# Altium schematic sheet FlightComp.SchDoc(FlightComp)
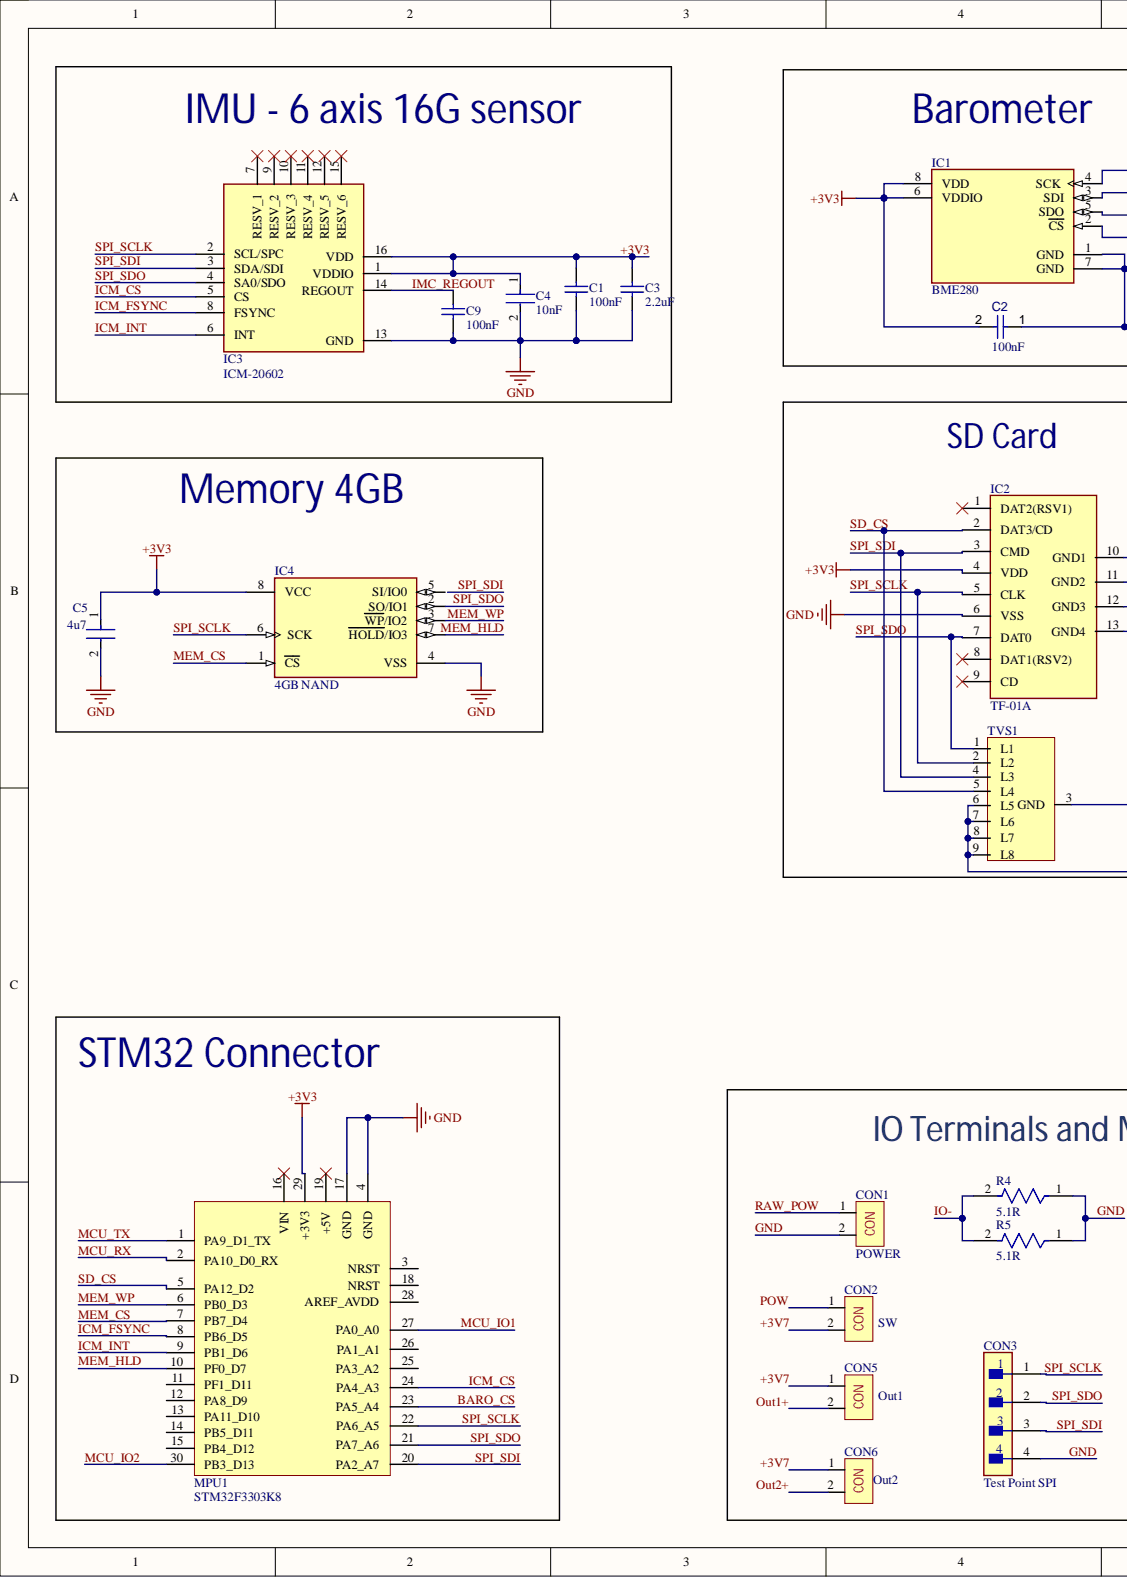
[diagram p0: FlightComp.SchDoc, left]
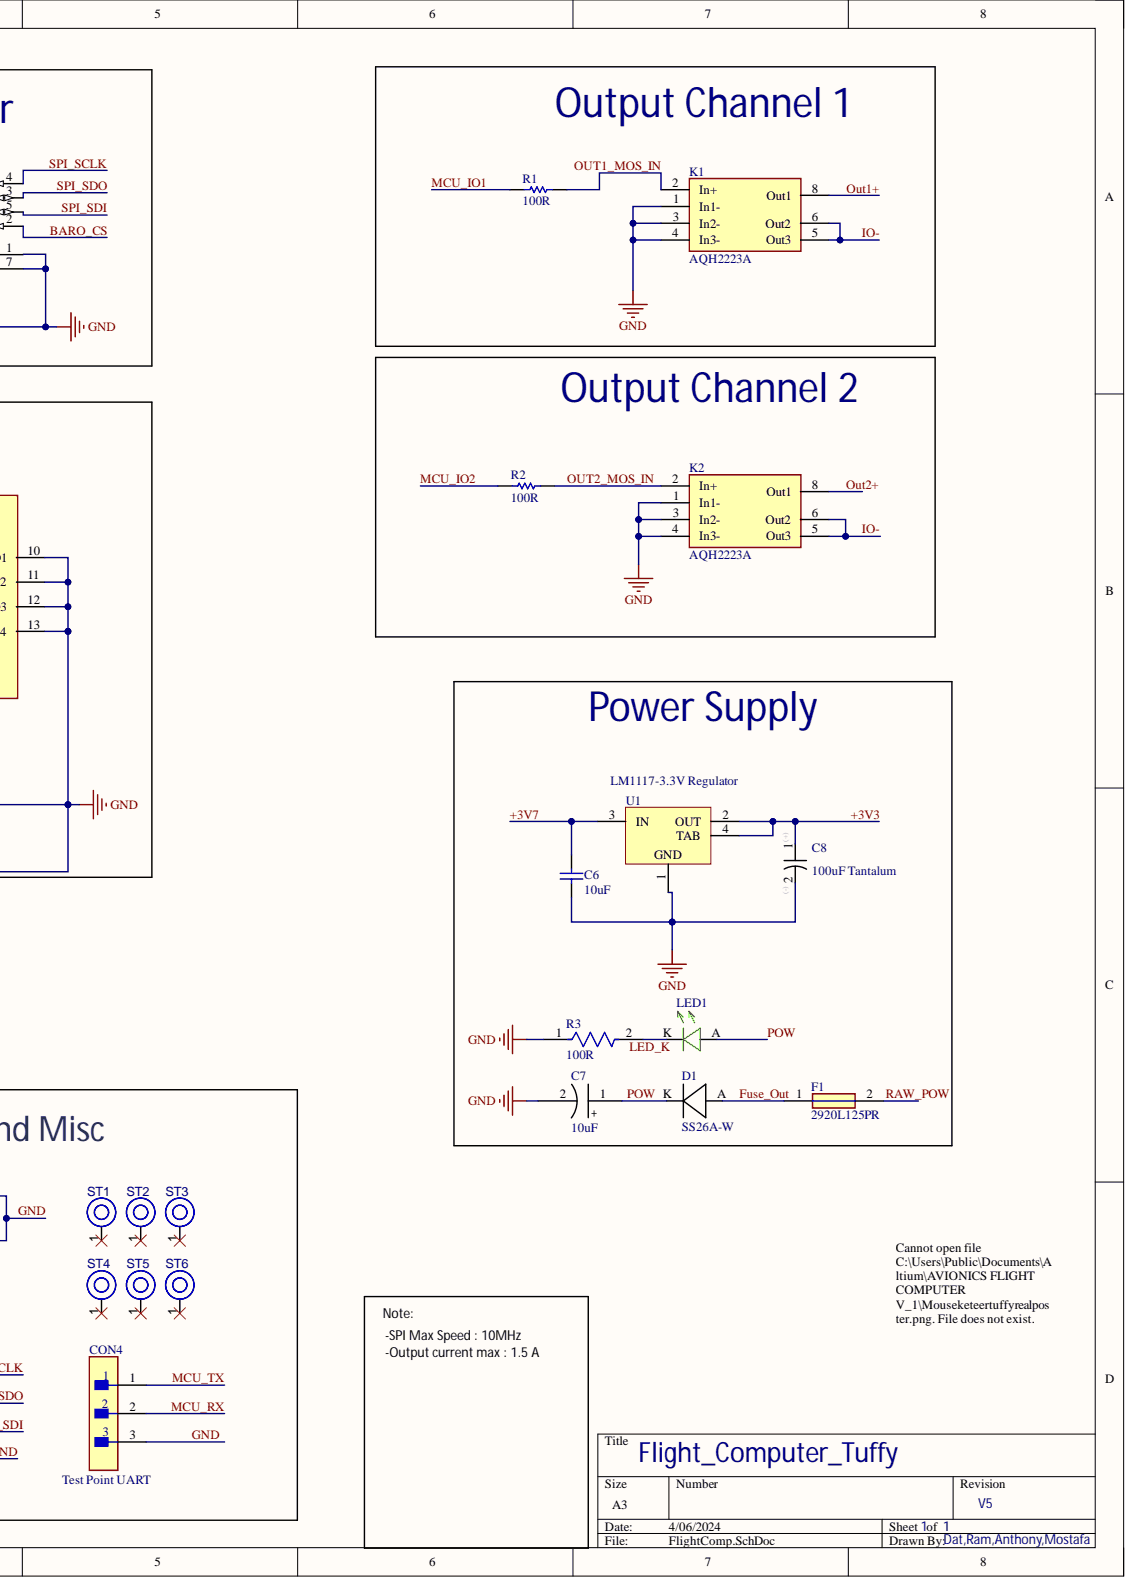
[diagram p1: FlightComp.SchDoc, right]

## Parts
C1  100nF
C2  value 100nF  (hidden comment: Capacitor 0.1 uF +/- 5% 16 V 0402)
C3  2.2uF
C4  10nF
C5  4u7
C6  10uF
C7  10uF
C8  100uF Tantalum  value 100uF
C9  100nF
CON1  POWER
CON2  SW
CON3  Test Point SPI
CON4  Test Point UART
CON5  Out1
CON6  Out2
D1  SS26A-W
F1  2920L125PR
IC1  BME280
IC2  TF-01A
IC3  ICM-20602
IC4  4GB NAND
K1  AQH2223A
K2  AQH2223A
LED1  SZYY0603YG  (value not drawn)
MPU1  STM32F3303K8
R1  100R
R2  100R
R3  100R
R4  5.1R
R5  5.1R
ST1  PTH-M2D5X5  (value not drawn)
ST2  PTH-M2D5X5  (value not drawn)
ST3  PTH-M2D5X5  (value not drawn)
ST4  PTH-M2D5X5  (value not drawn)
ST5  PTH-M2D5X5  (value not drawn)
ST6  PTH-M2D5X5  (value not drawn)
TVS1  D3V3X8U9LP3810  (value not drawn)
U1  LM1117-3.3V Regulator

## Nets
+3V3: C1.2 C2.2 C3.2 C4.1 C5.1 C8.+ IC1.6(VDDIO) IC1.8(VDD) IC2.4(VDD) IC3.1(VDDIO) IC3.16(VDD) IC4.8(VCC) MPU1.29(+3V3) U1.2(OUT) U1.4(TAB)
+3V7: C6.2 CON2.2 CON5.1 CON6.1 U1.3(IN)
BARO_CS: IC1.2(CS) MPU1.23(PA5_A4)
Fuse_Out: D1.A F1.1
GND: C1.1 C2.1 C3.1 C4.2 C5.2 C6.1 C7.2 C8.- C9.1 CON1.2 CON3.4 CON4.3 IC1.7(GND) IC2.6(VSS) IC2.10(GND1) IC2.11(GND2) IC2.12(GND3) IC2.13(GND4) IC3.13(GND) IC4.4(VSS) K1.1(In1-) K1.3(In2-) K1.4(In3-) K2.1(In1-) K2.3(In2-) K2.4(In3-) MPU1.4(GND) MPU1.17(GND) R3.1 R4.1 R5.1 TVS1.3(GND) TVS1.6(L5) TVS1.7(L6) TVS1.8(L7) TVS1.9(L8) U1.1
ICM_CS: IC3.5(CS) MPU1.24(PA4_A3)
ICM_FSYNC: IC3.8(FSYNC) MPU1.8(PB6_D5)
ICM_INT: IC3.6(INT) MPU1.9(PB1_D6)
IMC_REGOUT: C9.2 IC3.14(REGOUT)
IO-: K1.5(Out3) K1.6(Out2) K2.5(Out3) K2.6(Out2) R4.2 R5.2
LED_K: LED1.K R3.2
MCU_IO1: MPU1.27(PA0_A0) R1.1
MCU_IO2: MPU1.30(PB3_D13) R2.1
MCU_RX: CON4.2 MPU1.2(PA10_D0_RX)
MCU_TX: CON4.1 MPU1.1(PA9_D1_TX)
MEM_CS: IC4.1(CS) MPU1.7(PB7_D4)
MEM_HLD: IC4.7(HOLD/IO3) MPU1.10(PF0_D7)
MEM_WP: IC4.3(WP/IO2) MPU1.6(PB0_D3)
Out1+: CON5.2 K1.2(In+) K1.8(Out1) K2.2(In+) R1.2 R2.2  [also labelled OUT1_MOS_IN, OUT2_MOS_IN]
Out2+: CON6.2 K2.8(Out1)
POW: C7.1 CON2.1 D1.K LED1.A
RAW_POW: CON1.1 F1.2
SD_CS: IC2.2(DAT3/CD) MPU1.5(PA12_D2) TVS1.5(L4)
SPI_SCLK: CON3.1 IC1.4(SCK) IC2.5(CLK) IC3.2(SCL/SPC) IC4.6(SCK) MPU1.22(PA6_A5) TVS1.2(L2)
SPI_SDI: CON3.3 IC1.5(SDO) IC2.3(CMD) IC3.3(SDA/SDI) MPU1.20(PA2_A7) TVS1.4(L3)
SPI_SDO: CON3.2 IC1.3(SDI) IC2.7(DAT0) IC3.4(SA0/SDO) IC4.2(SO/IO1) MPU1.21(PA7_A6) TVS1.1(L1)

Not connected: IC1 1(GND); IC2 1(DAT2(RSV1)) 8(DAT1(RSV2)) 9(CD); IC3 7(RESV_1) 9(RESV_2) 10(RESV_3) 11(RESV_4) 12(RESV_5) 15(RESV_6); IC4 5(SI/IO0); MPU1 3(NRST) 11(PF1_D11) 12(PA8_D9) 13(PA11_D10) 14(PB5_D11) 15(PB4_D12) 16(VIN) 18(NRST) 19(+5V) 25(PA3_A2) 26(PA1_A1) 28(AREF_AVDD); ST1 1; ST2 1; ST3 1; ST4 1; ST5 1; ST6 1

## Library data of the parts
C1 C9 = CMP-0001-00074-2: Manufacturer: Kemet; Part Number: C0402C104K4RACTU; Component Kind: Standard; JLCPCB Part #: C2655418; Library Name: Altium Content Vault
C2 = CMP-001-00001-6: Chip Capacitor, 0.1 uF, +/- 5%, 16 V, 0402 (1005 Metric); Capacitor Type: Ceramic; Voltage Rating: 16V; Case/Package: 0402; Component Kind: Standard; JLCPCB Part #: C387941; Max Operating Temperature: 125°C; Min Operating Temperature: -55°C; Mounting Technology: SM; Pins: 2; RoHS Compliant: True; Tolerance: 5%; Library Name: Ram ganesh
C3 = CMP-2007-00007-1: Manufacturer: Kemet; Part Number: C0805C225K8RACTU; Component Kind: Standard; JLCPCB Part #: C342819; Library Name: Altium Content Vault
C4 = CMP-2010-00230-2: General Purpose Ceramic Capacitor, 0201, 10nF, 10%, X7R, 15%, 10V; Capacitance: 10nF; Case Code (Imperial): 0201; Case Code (Metric): 0603; Case/Package: 0201; Component Kind: Standard; Construction: Flat; Contact Plating: Tin; Depth: 0.3mm; Dielectric: X7R; Height: 0.33mm; JLCPCB Part #: C76941; Lead Free: Lead Free; Length: 0.6mm; Library Name: Altium Content Vault; Max Operating Temperature: 125°C; Min Operating Temperature: -55°C; Mount: Surface Mount; Package Quantity: 15000; Packaging: Tape and Reel; Radiation Hardening: No; REACH SVHC: No SVHC; RoHS Compliant: Yes; Series: -; Termination: SMD/SMT; Tolerance: 10%; Voltage: 10V; Voltage Rating: 10V; Voltage Rating (DC): 10V
C5 = CMP-2000-05997-2: CAP CER 4.7UF 10V X5R 0402; Dielectric Material: Ceramic; Tolerance: 10%; Capacitance: 4.7uF; Case Code (Imperial): 0402; Case Code (Metric): 1005; Case/Package: 0402; Component Kind: Standard; Dielectric: X5R; JLCPCB Part #: C368809; Lead Free: Lead Free; Length: 0.039inch; Library Name: Altium Content Vault; Max Operating Temperature: 85°C; Min Operating Temperature: -55°C; Mount: Surface Mount; Package Quantity: 10000; Packaging: Cut Tape; REACH SVHC: No SVHC; RoHS Compliant: Yes; Series: C; Thickness: 0.022inch; Voltage Rating: 10V; Voltage Rating (DC): 10V; Width: 0.02inch
C6 = CMP-1037-04961-1: CAP 10uF 6.3V ±10% 1206 (3216 Metric) Thickness 1.9mm SMD; Case-EIA: 1206; Case-Metric: 3216; Tolerance: Â±10%; Component Kind: Standard; JLCPCB Part #: C157351; Library Name: Altium Content Vault; Max Thickness: 1.9 mm; Rated Voltage: 6.3 V; Technology: SMT; Value: 10uF
C7 = RVT2A100M0607: 10uF 100V 38mA@120Hz ±20% SMD,D6.3xL7.7mm Aluminum Electrolytic Capacitors - SMD ROHS; Capacitance: 10uF; Component Kind: Standard; ComponentLink1Description: Info; ComponentLink1URL: https://jlcpcb.com/partdetail/Roqang-RVT2A100M0607/C72482; JLCPCB Part #: C72482; Library Name: FlightComputer.SchLib
C8 = T55A107M6R3C0150: 100uF 6.3V 150mΩ@100kHz ±20% 1206 Tantalum Capacitors ROHS; Parameter 1: C2158718; Component Kind: Standard; ComponentLink1Description: Part Shop; ComponentLink1URL: https://jlcpcb.com/partdetail/VishayIntertech-T55A107M6R3C0150/C2158718; JLCPCB Part #: C2158718; Library Name: FlightComputer.SchLib
CON1 CON2 CON5 CON6 = 4948978-2 (RS Stock): RS PRO PCB Terminal Block, 2-Contact, 5mm Pitch, Through Hole Mount, 1-Row, Screw Termination; Component Kind: Standard; ComponentLink1Description: Data Sheet; ComponentLink1URL: https://au.rs-online.com/web/p/pcb-terminal-blocks/4948978; Library Name: FlightComputer.SchLib
CON3 = PZ254V-11-04P: 250V 3A Straight Square Pins 2.5mm 4P 6mm -40℃~+105℃ 3mm 2.54mm Plugin Black 1x4P Plugin,P=2.54mm Pin Headers ROHS; Component Kind: Standard; Library Name: FlightComputer.SchLib
CON4 = PZ254V-11-03P: 250V 3A Straight Square Pins 2.5mm 3P 6mm -40℃~+105℃ 3mm 2.54mm Plugin Black 1x3P Plugin,P=2.54mm Pin Headers ROHS; Component Kind: Standard; ComponentLink1Description: Data Sheet; ComponentLink1URL: https://jlcpcb.com/partdetail/Xfcn-PZ254V_1103P/C2937625; Library Name: FlightComputer.SchLib
F1 = 2920L125PR: Fuse; Manufacturer_Name: LITTELFUSE; Arrow Part Number: 2920L125PR; Arrow Price/Stock: https://www.arrow.com/en/products/2920l125pr/littelfuse?region=nac; Component Kind: Standard; Datasheet Link: https://datasheet.lcsc.com/szlcsc/1907051732_Littelfuse-2920L125PR_C192524.pdf; Height: 1mm; JLCPCB Part #: C192524; Manufacturer_Part_Number: 2920L125PR; Mouser Part Number: 576-2920L125; Mouser Price/Stock: https://www.mouser.co.uk/ProductDetail/Littelfuse/2920L125PR?qs=SJZ%252BTX%252BI2BSHRP6GPsgTlw%3D%3D
IC1 = CMP-2000-05073-3: SENSOR PRESSURE HUMIDITY TEMP; Accuracy: 3%; Output Type: SPI; Response Time: 1s; Sensor Type: Temperature; Case/Package: LGA; Component Kind: Standard; JLCPCB Part #: C92489; Lead Free: Lead Free; Library Name: Altium Content Vault; Max Operating Temperature: 85°C; Min Operating Temperature: -40°C; Mount: Surface Mount; Number of Pins: 8; Packaging: Cut Tape
IC2 = TF-01A: Connector; Manufacturer_Name: Korean Hroparts Elec; Component Kind: Standard; Datasheet Link: https://datasheet.lcsc.com/szlcsc/1903221101_Korean-Hroparts-Elec-TF-01A_C91145.pdf; Height: 1.85mm; JLCPCB Part #: C91145; Library Name: FlightComputer.SchLib; Manufacturer_Part_Number: TF-01A
IC3 = ICM-20602: High Performance 6-Axis MEMS MotionTracking™ Device; Manufacturer_Name: TDK-Lambda; Arrow Part Number: ICM-20602; Arrow Price/Stock: https://www.arrow.com/en/products/icm-20602/invensense?region=nac; Component Kind: Standard; Datasheet Link: https://3cfeqx1hf82y3xcoull08ihx-wpengine.netdna-ssl.com/wp-content/uploads/2021/03/DS-000135-ICG-20660-TYP-v1.2.pdf; JLCPCB Part #: C97633; Library Name: FlightComputer.SchLib; Manufacturer_Part_Number: ICM-20602; Mouser Part Number: 410-ICM-20602; Mouser Price/Stock: https://www.mouser.co.uk/ProductDetail/TDK-InvenSense/ICM-20602?qs=u4fy%2FsgLU9NtDChFbqTxBA%3D%3D
IC4 = CMP-14958-000017-1: IC FLASH 4GBIT SPI UPDFN; Memory Type: Non-Volatile; Component Kind: Standard; JLCPCB Part #: C2909506; Library Name: Altium Content Vault; Max Operating Temperature: 85°C; Memory Size: 4Gb; Min Operating Temperature: -40°C; Packaging: Box; Series: -
K1 K2 = AQH2223A: Relay or Contactor; Manufacturer_Name: Panasonic; Component Kind: Standard; Datasheet Link: https://b2b-api.panasonic.eu/file_stream/pids/fileversion/2787; Height: 3.9mm; JLCPCB Part #: C153026; Library Name: FlightComputer.SchLib; Manufacturer_Part_Number: AQH2223A; Mouser Part Number: 769-AQH2223A; Mouser Price/Stock: https://www.mouser.co.uk/ProductDetail/Panasonic-Industrial-Devices/AQH2223A?qs=g5ciJ0jwZaFQToN6HqT%252B2g%3D%3D
LED1 = SZYY0603YG: Green LED 0603; Component Kind: Standard; ComponentLink1Description: Data Sheet; ComponentLink1URL: https://jlcpcb.com/partdetail/YongyuPhotoelectric-SZYY0603YG/C434423; JLCPCB Part #: C434423; Library Name: FlightComputer.SchLib
MPU1 = NUCLEO_STM32F303K8: NuCleo STM32F303K8 PCB connector; Component Kind: Standard; Library Name: FlightComputer.SchLib; System: Connector
R1 R2 = CMP-2100-03669-1: Chip Resistor, 100 Ohm, +/-1%, 0.125 W, -55 to 155 degC, 0805 (2012 Metric), RoHS, Tape and Reel; Manufacturer: Yageo; Max Power Dissipation: 125mW; Resistance: 100Ohm; Temperature Coefficient: 100ppm/degreesC; Tolerance: 1%; Voltage Rating: 150V; Case Code (Imperial): 0805; Case Code (Metric): 2012; Case/Package: 0805; Component Kind: Standard; Composition: ThickFilm; Datasheet URL: http://www.yageo.com/NewPortal/yageodocoutput?fileName=/pdf/R-Chip/PYu-RC_Group_51_RoHS_L_5.pdf; Datasheet Version: Rev. 5, 10/2016; Depth: 1.25mm; Height: 0.024inch; Lead Free: LeadFree; Lead Pitch: 0.4mm; Length: 2mm; Library Name: Altium Content Vault; Manufacturer URL: http://www.yageo.com/; Max Operating Temperature: 155degC; Military Standard: Not; Min Operating Temperature: -55degC; Number of Pins: 2; Number of Terminations: 2; Package Description: 2-Pin Surface Mounted Device, Body 2 x 1.25 mm; Package Quantity: 1; Package Reference: 0805; Packaging: TapeandReel; Power Rating: 125mW; REACH SVHC: Unknown; RoHSCompliant: true; Termination: SMD/SMT; Voltage Rating (DC): 150V; Width: 0.049inch
R3 = CMP-1015-00050-3: RES SMD 205K OHM 1% 1/2W 1896; Max Power Dissipation: 500mW; Temperature Coefficient: 200ppm/°C; Tolerance: 5%; Voltage Rating: 200V; Case Code (Imperial): 1210; Case Code (Metric): 3225; Case/Package: 1210; Component Kind: Standard; Composition: Thick Film; Contact Plating: Tin; Depth: 2.5mm; Features: Automotive AEC-Q200; Height: 0.024inch; JLCPCB Part #: C153399; Lead Free: Lead Free; Length: 3.2mm; Library Name: Altium Content Vault; Max Operating Temperature: 155°C; Military Standard: Not; Min Operating Temperature: -55°C; Number of Terminations: 2; Package Quantity: 1; Packaging: Tape and Reel; Power Rating: 500mW; Radiation Hardening: No; Resistance: 100R; Termination: SMD/SMT; Voltage Rating (DC): 200V; Weight: 0.000564oz; Width: 0.098inch
R4 R5 = CMP-02407-007932-1: RPL-12L5R10FT; Max Power Dissipation: 1W; Temperature Coefficient: 200ppm/°C; Tolerance: 5%; Voltage Rating: 500V; Case Code (Imperial): 2512; Case Code (Metric): 6432; Case/Package: 2512; Component Kind: Standard; Composition: Thick Film; Contact Plating: Tin; Depth: 3.15mm; Features: Automotive AEC-Q200; Height: 0.6mm; JLCPCB Part #: C73113; Lead Free: Lead Free; Length: 6.3mm; Library Name: Altium Content Vault; Max Operating Temperature: 155°C; Military Standard: Not; Min Operating Temperature: -55°C; Number of Pins: 2; Number of Terminations: 2; Package Quantity: 1; Packaging: Tape and Reel; Power Rating: 1W; Resistance: 5.1R; Termination: Solder; Voltage Rating (DC): 500V; Weight: 0.001429oz; Width: 3.15mm
ST1-ST6 = CMP-00028-00004-2: M2 PTH (2.5mm), 5mm pad; Component Kind: Standard; Pins: 1; Library Name: Ram ganesh
U1 = CMP-0062-01639-4: 800mA Low-Dropout Linear Regulator, 4-pin SOT-223, Pb-Free; Manufacturer: Texas Instruments; PartNumber: LM1117MPX-3.3/NOPB; CompType: LDO IC; High-Reliability: Y; PackageTopMarking: XYTT*N05A; PowerWise: N; Code_IPC: SOT223-4; Code_JEDEC: TO-261-AA; Component Kind: Standard; ComponentLink1Description: Datasheet URL; ComponentLink1URL: http://www.ti.com/general/docs/lit/getliterature.tsp?genericPartNumber=LM1117-N&fileType=pdf; JLCPCB Part #: C9662; Library Name: Altium Content Vault; PackageDescription: Molded SOT-223, 6.50 x 3.56 x 1.6mm Body, 4 Lead, 2.3mm Pitch; PackageReference: DCY0004A; PinCount: 4; RoHS: Y
D1 = SS26A-W: Component Kind: Standard; ComponentLink1Description: Website; ComponentLink1URL: https://jlcpcb.com/partdetail/Zhide-SS26AW/C2683691; JLCPCB Part #: C2683691; Library Name: FlightComputer.SchLib
TVS1 = D3V3X8U9LP3810: Component Kind: Standard; JLCPCB Part #: C1973794; Library Name: FlightComputer.SchLib
